# Altium schematic sheet Extension.SchDoc(Extension)
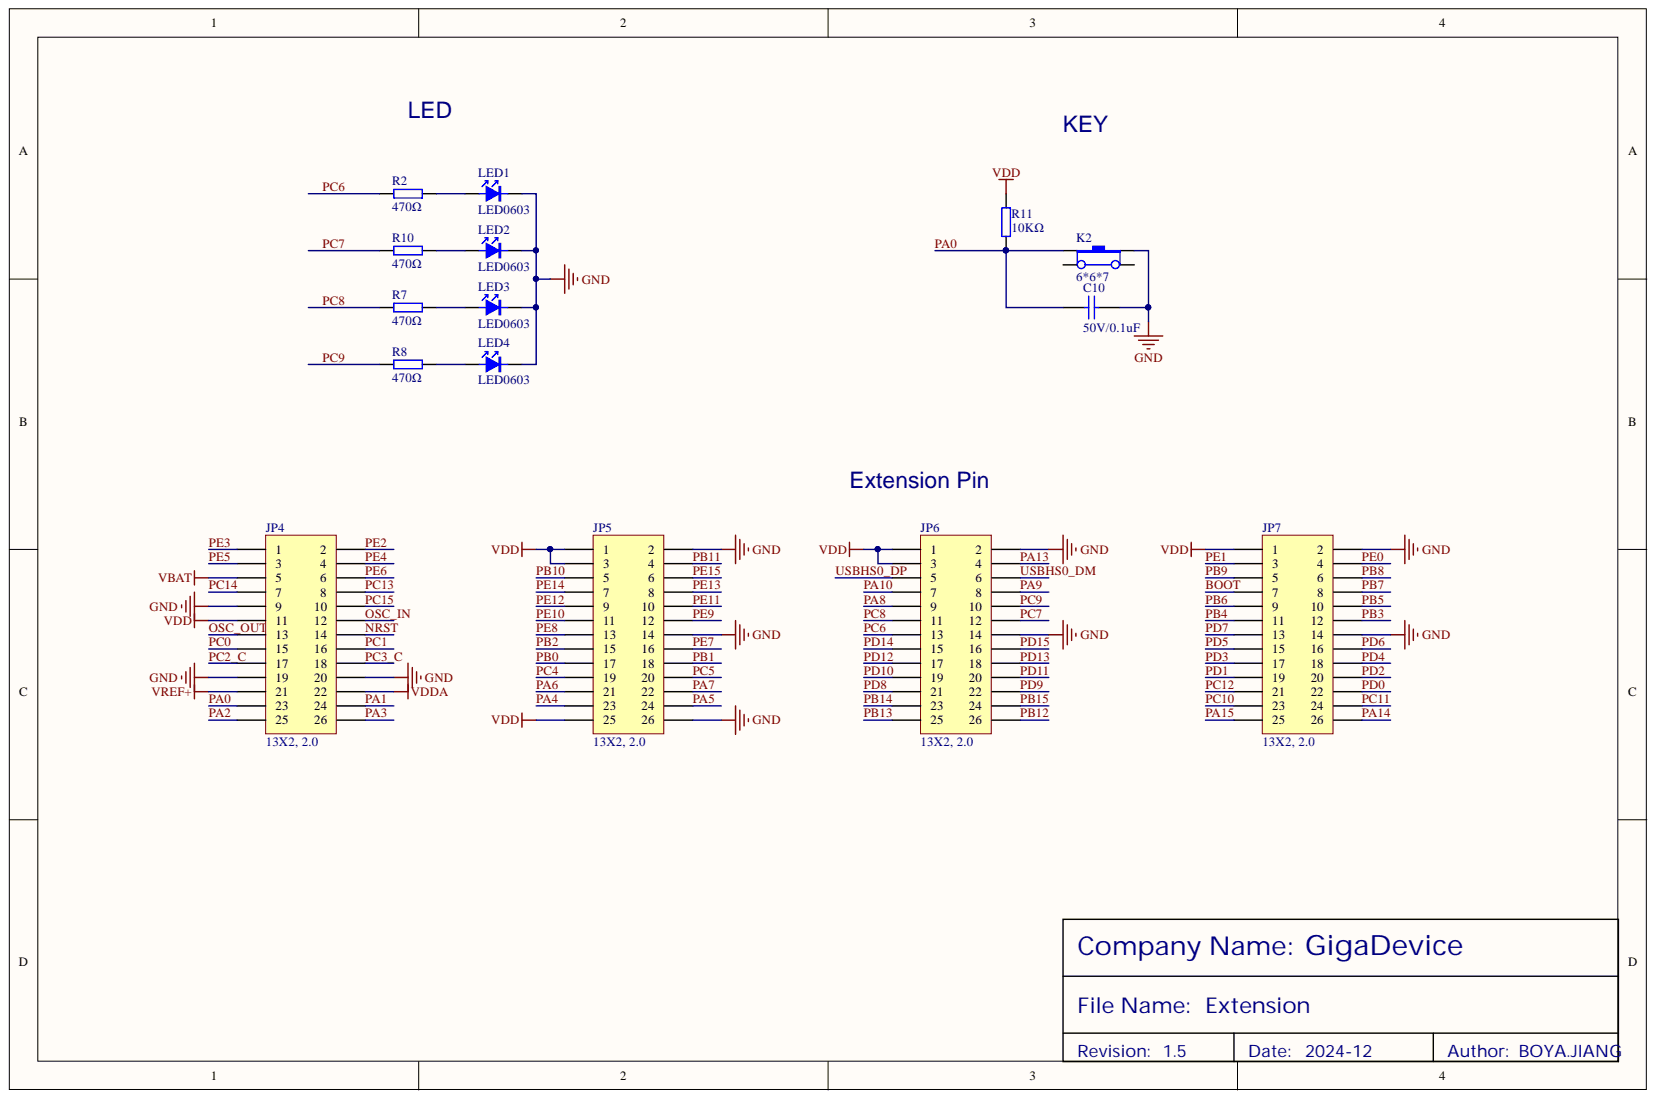
[diagram p0: Extension.SchDoc]

## Parts
C10  50V/0.1uF  [0603-C]
JP4  13X2, 2.0  [IDC-26-2.0]
JP5  13X2, 2.0  [IDC-26-2.0]
JP6  13X2, 2.0  [IDC-26-2.0]
JP7  13X2, 2.0  [IDC-26-2.0]
K2  6*6*7  [K-1102B]
LED1  LED0603  [0603-LED-Green]
LED2  LED0603  [0603-LED-Green]
LED3  LED0603  [0603-LED-Green]
LED4  LED0603  [0603-LED-Green]
R2  470Ω  [0603-R]
R7  470Ω  [0603-R]
R8  470Ω  [0603-R]
R10  470Ω  [0603-R]
R11  10KΩ  [0603-R]

## Nets
GND: C10.2 JP4.9 JP4.19 JP4.20 JP5.2 JP5.14 JP5.26 JP6.2 JP6.14 JP7.2 JP7.14 K2.1 LED1.K LED2.K LED3.K LED4.K
VBAT: JP4.5
VDD: JP4.11 JP5.1 JP5.3 JP5.25 JP6.1 JP6.3 JP7.1 R11.1
VDDA: JP4.22
VREF+: JP4.21
BOOT: JP7.7
NRST: JP4.14
OSC_IN: JP4.12
OSC_OUT: JP4.13
PA0: C10.1 JP4.23 K2.3 R11.2
PA1: JP4.24
PA2: JP4.25
PA3: JP4.26
PA4: JP5.23
PA5: JP5.24
PA6: JP5.21
PA7: JP5.22
PA8: JP6.9
PA9: JP6.8
PA10: JP6.7
PA13: JP6.4
PA14: JP7.26
PA15: JP7.25
PB0: JP5.17
PB1: JP5.18
PB2: JP5.15
PB3: JP7.12
PB4: JP7.11
PB5: JP7.10
PB6: JP7.9
PB7: JP7.8
PB8: JP7.6
PB9: JP7.5
PB10: JP5.5
PB11: JP5.4
PB12: JP6.26
PB13: JP6.25
PB14: JP6.23
PB15: JP6.24
PC0: JP4.15
PC1: JP4.16
PC2_C: JP4.17
PC3_C: JP4.18
PC4: JP5.19
PC5: JP5.20
PC6: JP6.13 R2.1
PC7: JP6.12 R10.1
PC8: JP6.11 R7.1
PC9: JP6.10 R8.1
PC10: JP7.23
PC11: JP7.24
PC12: JP7.21
PC13: JP4.8
PC14: JP4.7
PC15: JP4.10
PD0: JP7.22
PD1: JP7.19
PD2: JP7.20
PD3: JP7.17
PD4: JP7.18
PD5: JP7.15
PD6: JP7.16
PD7: JP7.13
PD8: JP6.21
PD9: JP6.22
PD10: JP6.19
PD11: JP6.20
PD12: JP6.17
PD13: JP6.18
PD14: JP6.15
PD15: JP6.16
PE0: JP7.4
PE1: JP7.3
PE2: JP4.2
PE3: JP4.1
PE4: JP4.4
PE5: JP4.3
PE6: JP4.6
PE7: JP5.16
PE8: JP5.13
PE9: JP5.12
PE10: JP5.11
PE11: JP5.10
PE12: JP5.9
PE13: JP5.8
PE14: JP5.7
PE15: JP5.6
USBHS0_DM: JP6.6
USBHS0_DP: JP6.5
NetLED1_A: LED1.A R2.2
NetLED2_A: LED2.A R10.2
NetLED3_A: LED3.A R7.2
NetLED4_A: LED4.A R8.2

Not connected: K2 2 4

## Library data of the parts
C10 = 50V/0.1uF: 表贴电容; Component Kind: Standard; Library Name: KLTC-电容.SchLib
JP4-JP7 = HEADER 13X2: 双排插针; Component Kind: Standard; Library Name: KLTC-按键及接插件.SchLib
K2 = K-1102B: 普通6X6X7直插按键; Component Kind: Standard; Library Name: KLTC-按键及接插件.SchLib
LED1-LED4 = LED0603: 表贴LED发光管; Component Kind: Standard; Library Name: xufei.SchLib
R2 R7 R8 R10 = 680Ω: 表贴电阻; Component Kind: Standard; Library Name: KLTC-电阻.SchLib
R11 = 10KΩ: 表贴电阻; Component Kind: Standard; Library Name: KLTC-电阻.SchLib
